# Altium schematic sheet 3A03xx_Oxocard_Mini_Basic_MCU.SchDoc
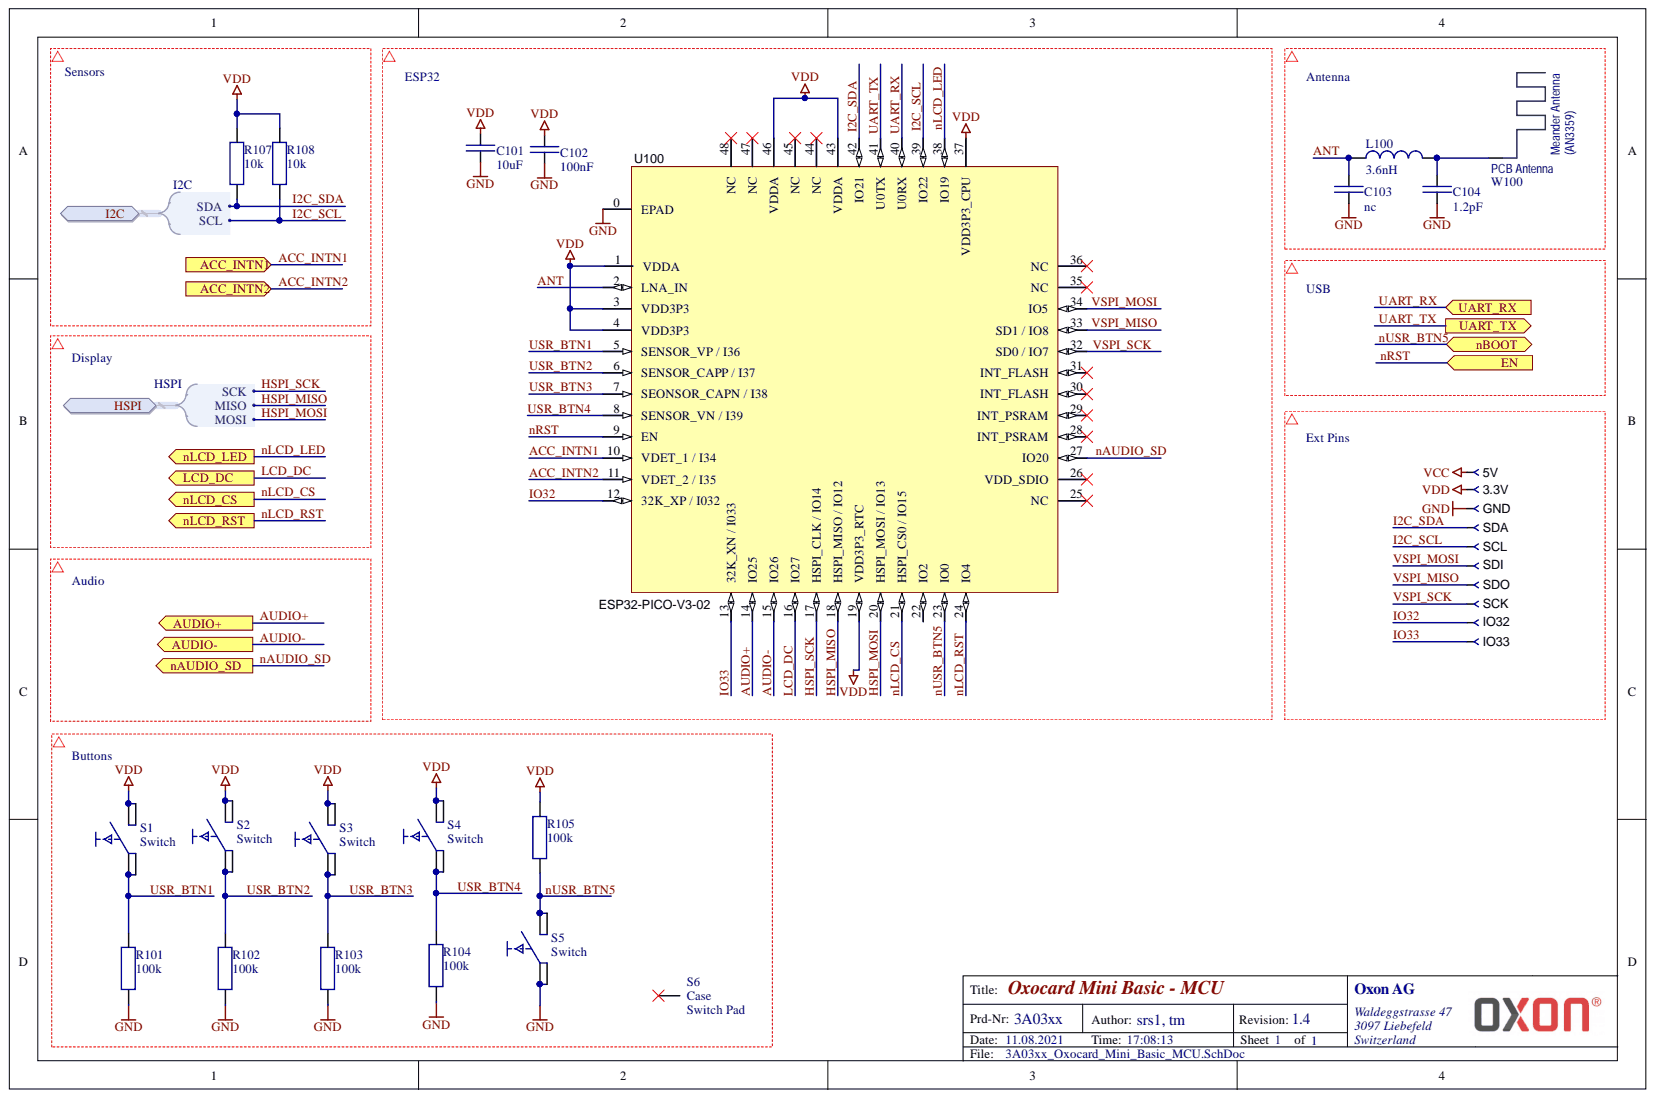
[diagram p0: 3A03xx_Oxocard_Mini_Basic_MCU.SchDoc]

## Parts
3.3V  TP-2x3mm  [TP_2X3MM]  (value not drawn)
5V  TP-2x3mm  [TP_2X3MM]  (value not drawn)
C101  10uF  [CAPC1005X60]
C102  100nF  [CAPC1005X60]
C103  nc  [CAPC1005X60_NC]
C104  1.2pF  [CAPC1005X60]
GND  TP-2x3mm  [TP_2X3MM]  (value not drawn)
IO32  TP-2x3mm  [TP_2X3MM]  (value not drawn)
IO33  TP-2x3mm  [TP_2X3MM]  (value not drawn)
L100  3.6nH  [INDC1005X60]
R101  100k  [RESC1005X40]
R102  100k  [RESC1005X40]
R103  100k  [RESC1005X40]
R104  100k  [RESC1005X40]
R105  100k  [RESC1005X40]
R107  10k  [RESC1005X40]
R108  10k  [RESC1005X40]
S1  Switch  [Switch_Frog_7.6x7.6]
S2  Switch  [Switch_Frog_7.6x7.6]
S3  Switch  [Switch_Frog_7.6x7.6]
S4  Switch  [Switch_Frog_7.6x7.6]
S5  Switch  [Switch_Frog_7.6x7.6]
S6  Switch Pad  [Switch_PAD]
SCK  TP-2x3mm  [TP_2X3MM]  (value not drawn)
SCL  TP-2x3mm  [TP_2X3MM]  (value not drawn)
SDA  TP-2x3mm  [TP_2X3MM]  (value not drawn)
SDI  TP-2x3mm  [TP_2X3MM]  (value not drawn)
SDO  TP-2x3mm  [TP_2X3MM]  (value not drawn)
U100  ESP32-PICO-V3-02  [QFN48-7x7mm]
W100  BLE-Antenna  [W-BLE-PCB-ANT]  (value not drawn)

## Ports
ACC_INTN1, ACC_INTN2, AUDIO+, AUDIO-, EN, HSPI, HSPI.MISO, HSPI.MOSI, HSPI.SCK, I2C, I2C.SCL, I2C.SDA, LCD_DC, nAUDIO_SD, nBOOT, nLCD_CS, nLCD_LED, nLCD_RST, UART_RX, UART_TX

## Nets
GND: C101.1 C102.1 C103.1 C104.1 GND.1 R101.1 R102.1 R103.1 R104.1 S5.1 S5.2 U100.0(EPAD)
VCC: 5V.1
VDD: 3.3V.1 C101.2 C102.2 R105.2 R107.2 R108.2 S1.3 S1.4 S2.3 S2.4 S3.3 S3.4 S4.3 S4.4 U100.1(VDDA) U100.3(VDD3P3) U100.4(VDD3P3) U100.19(VDD3P3_RTC) U100.37(VDD3P3_CPU) U100.43(VDDA) U100.46(VDDA)
ACC_INTN1: U100.10(VDET_1_/_I34) ; port ACC_INTN1
ACC_INTN2: U100.11(VDET_2_/_I35) ; port ACC_INTN2
ANT: C103.2 L100.1 U100.2(LNA_IN)
AUDIO+: U100.14(IO25) ; port AUDIO+
AUDIO-: U100.15(IO26) ; port AUDIO-
HSPI_MISO: U100.18(HSPI_MISO_/_IO12) ; port HSPI
HSPI_MOSI: U100.20(HSPI_MOSI_/_IO13) ; port HSPI
HSPI_SCK: U100.17(HSPI_CLK_/_IO14) ; port HSPI
I2C_SCL: R108.1 SCL.1 U100.39(IO22) ; port I2C
I2C_SDA: R107.1 SDA.1 U100.42(IO21) ; port I2C
IO32: IO32.1 U100.12(32K_XP_/_I032)
IO33: IO33.1 U100.13(32K_XN_/_I033)
LCD_DC: U100.16(IO27) ; port LCD_DC
nAUDIO_SD: U100.27(IO20) ; port nAUDIO_SD
nLCD_CS: U100.21(HSPI_CS0_/_IO15) ; port nLCD_CS
nLCD_LED: U100.38(IO19) ; port nLCD_LED
nLCD_RST: U100.24(IO4) ; port nLCD_RST
nRST: U100.9(EN) ; port EN
nUSR_BTN5: R105.1 S5.3 S5.4 U100.23(IO0) ; port nBOOT
UART_RX: U100.40(U0RX) ; port UART_RX
UART_TX: U100.41(U0TX) ; port UART_TX
USR_BTN1: R101.2 S1.1 S1.2 U100.5(SENSOR_VP_/_I36)
USR_BTN2: R102.2 S2.1 S2.2 U100.6(SENSOR_CAPP_/_I37)
USR_BTN3: R103.2 S3.1 S3.2 U100.7(SEONSOR_CAPN_/_I38)
USR_BTN4: R104.2 S4.1 S4.2 U100.8(SENSOR_VN_/_I39)
VSPI_MISO: SDO.1 U100.33(SD1_/_IO8)
VSPI_MOSI: SDI.1 U100.34(IO5)
VSPI_SCK: SCK.1 U100.32(SD0_/_IO7)
NetC104_2: C104.2 L100.2 W100.1

Not connected: S6 0; U100 22(IO2) 25(NC) 26(VDD_SDIO) 28(INT_PSRAM) 29(INT_PSRAM) 30(INT_FLASH) 31(INT_FLASH) 35(NC) 36(NC) 44(NC) 45(NC) 47(NC) 48(NC)

## Library data of the parts
3.3V 5V GND IO32 IO33 SCK SCL SDA SDI SDO = TP-20x30-SMT: Test Point, 2x3mm; Component Kind: Standard; Library Name: XLib.SCHLIB
C101 = C-CAP0402_10u: SMD capacitor 6.3V 20%; Manufacturer: Wurth Electronics; Manufacturer Designator: ZRB15XR60J106ME2D; Component Kind: Standard; Distributor: Mouser; Distributor Part-Number: 81-ZRB15XR60J106ME2D; Library Name: XLib.SCHLIB
C102 = C-CAP0402_100n: SMD capacitor 10V 5%; Manufacturer: Wurth Electronics; Manufacturer Designator: GRM155R61A104JA1D; Component Kind: Standard; Distributor: Mouser; Distributor Part-Number: 81-GRM155R61A104JA1D; Library Name: XLib.SCHLIB
C103 = C-CAP0402_nc: SMD capacitor nc; Component Kind: Standard; Distributor: -; Distributor Part-Number: -; Library Name: XLib.SCHLIB; Manufacturer: -; Manufacturer Designator: -
C104 = C-CAP0402_1p2: SMD capacitor 50V +/-0.05pF; Manufacturer: Murata Electronics; Manufacturer Designator: GJM1555C1H1R2WB01J; Component Kind: Standard; Distributor: Mouser; Distributor Part-Number: 81-GJM1555C1H1R2WB1J; Library Name: XLib.SCHLIB
L100 = L-Ind00402_3n6: SMD induction 2%; Manufacturer: muRata; Manufacturer Designator: LQW15AN3N6G80D; Component Kind: Standard; Distributor: Mouser; Distributor Part-Number: 81-LQW15AN3N6G80D; Library Name: XLib.SCHLIB
R101-R105 = R-RES0402_100k: SMD resistor 1%; Manufacturer: Yageo; Manufacturer Designator: RC0402FR-07100KL; Component Kind: Standard; Distributor: Mouser; Distributor Part-Number: 603-RC0402FR-07100KL; Library Name: XLib.SCHLIB
R107 R108 = R-RES0402_10k: SMD resistor 1%; Manufacturer: Yageo; Manufacturer Designator: RC0402FR-0710KL; Component Kind: Standard; Distributor: Mouser; Distributor Part-Number: 603-RC0402FR-0710KL; Library Name: XLib.SCHLIB
S1-S5 = S-Frog-7.6x7.6: Switch Frog 7.6x7.6; Manufacturer: KEJIAN Elekctronics; Manufacturer Designator: TVFF01-007VW; Component Kind: Standard; Distributor: KEJIAN Elekctronics; Distributor Part-Number: TVFF01-007VW; Library Name: XLib.SCHLIB
S6 = S-SwitchPad: Component Kind: Standard; Description: Switch pad; Library Name: XLib.SCHLIB
U100 = U-ESP32-PICO-V3-02: ESP32 8MB Flash 2MB PSRAM; Manufacturer: Espressif Systems; Component Kind: Standard; Distributor: Mouser; Distributor Part-Number: 356-ESP32-PICO-V3-02; Library Name: XLib.SCHLIB; Manufacturer Designator: ESP32-PICO-V3-02
W100 = W-BLE-PCB-Antenna: 2.4GHz PCB Antenna; Component Kind: Standard; Distributor: -; Distributor Part-Number: -; Library Name: XLib.SCHLIB; Manufacturer: -; Manufacturer Designator: -
